# Altium schematic sheet 走马灯SCH.SchDoc(走马灯SCH)
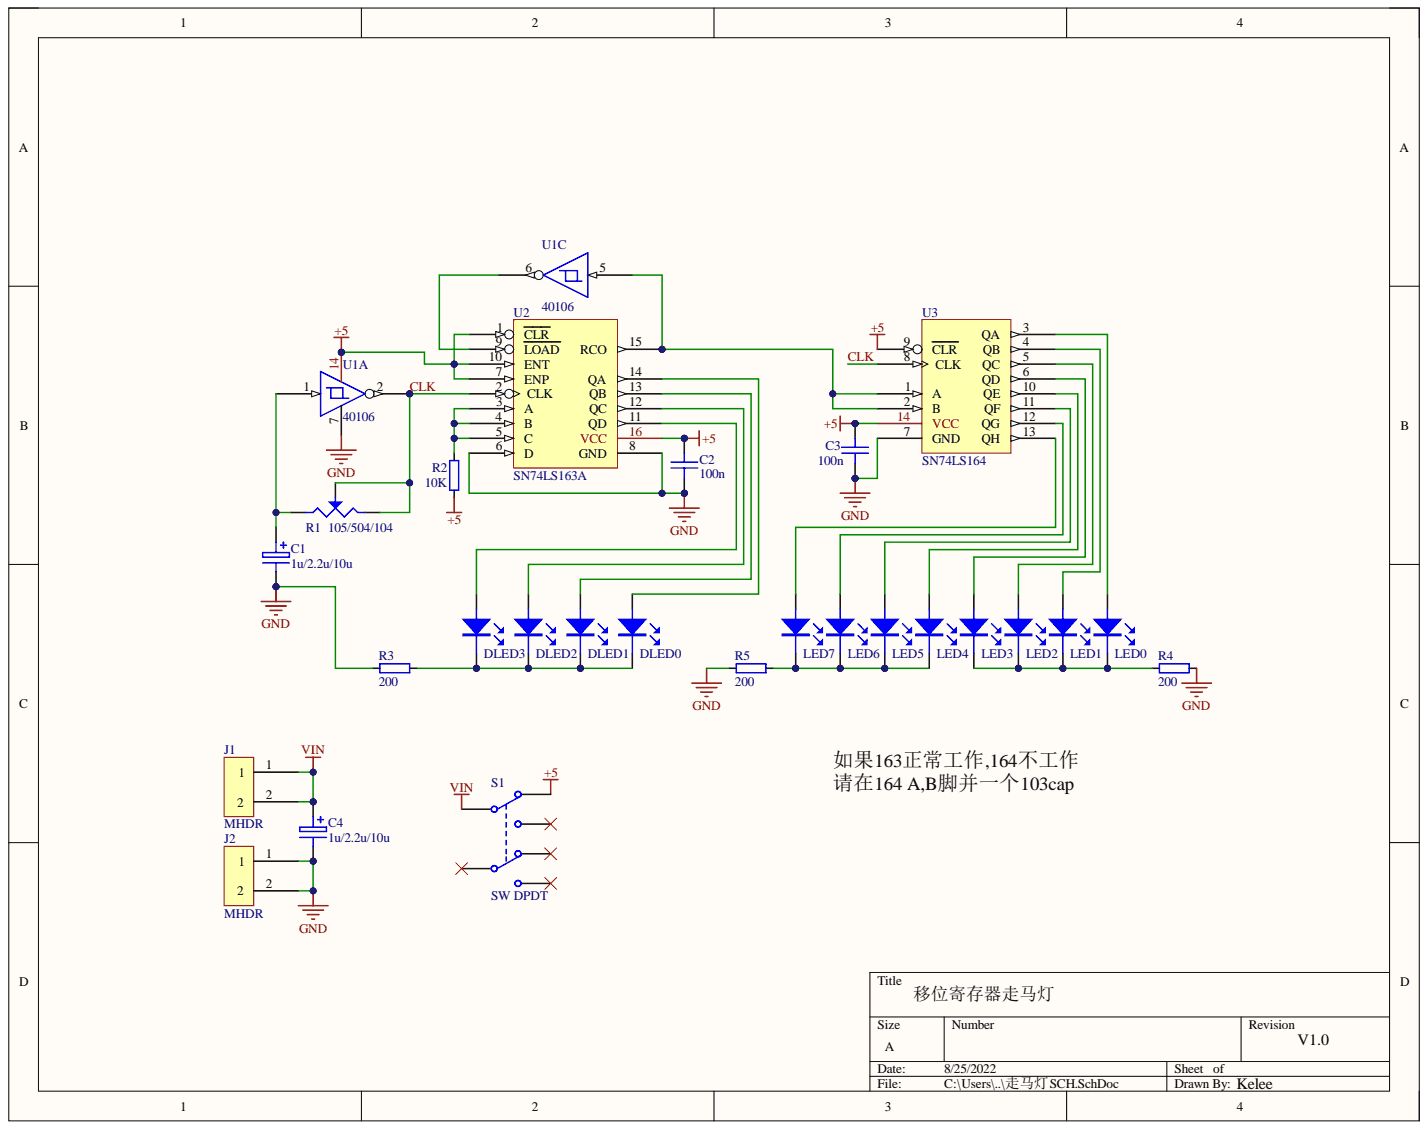
[diagram p0: 走马灯SCH.SchDoc]

## Parts
C1  1u/2.2u/10u  [C5X8]
C2  100n  [MLCC5.08-A]
C3  100n  [MLCC5.08-A]
C4  1u/2.2u/10u  [C5X8]
DLED0  D-LED  [LED 5MM-Y]
DLED1  D-LED  [LED 5MM-Y]
DLED2  D-LED  [LED 5MM-Y]
DLED3  D-LED  [LED 5MM-Y]
J1  MHDR  [HDR1X2]
J2  MHDR  [HDR1X2]
LED0  LED  [LED 5MM-R]
LED1  LED  [LED 5MM-R]
LED2  LED  [LED 5MM-R]
LED3  LED  [LED 5MM-R]
LED4  LED  [LED 5MM-R]
LED5  LED  [LED 5MM-R]
LED6  LED  [LED 5MM-R]
LED7  LED  [LED 5MM-R]
R1  105/504/104  [RES ADJ3]
R2  10K  [RS 1/4W]
R3  200  [RS 1/4W]
R4  200  [RS 1/4W]
R5  200  [RS 1/4W]
S1  SW DPDT  [KFT DIP-8X8]
U1  40106  [DIP14]  (parts A,C)
U2  SN74LS163A  [DIP16]
U3  SN74LS164  [DIP14]

## Nets
+5: C2.2 C3.2 R2.2 S1.1 U1.14 U2.1(CLR) U2.7(ENP) U2.10(ENT) U2.16(VCC) U3.9(CLR) U3.14(VCC)
GND: C1.2 C2.1 C3.1 C4.2 J2.1 J2.2 R3.1 R4.2 R5.1 U1.7 U2.6(D) U2.8 U3.7(GND)
VCC: U1.14 U2.16(VCC) U3.14(VCC)
VIN: C4.1 J1.1 J1.2 S1.3
CLK: R1.2 R1.3 U1.2 U2.2(CLK) U3.8(CLK)
NetC1_1: C1.1 R1.1 U1.1
NetDLED0_1: DLED0.1 U2.14(QA)
NetDLED0_2: DLED0.2 DLED1.2 DLED2.2 DLED3.2 R3.2
NetDLED1_1: DLED1.1 U2.13(QB)
NetDLED2_1: DLED2.1 U2.12(QC)
NetDLED3_1: DLED3.1 U2.11(QD)
NetLED0_1: LED0.1 U3.3(QA)
NetLED0_2: LED0.2 LED1.2 LED2.2 LED3.2 R4.1
NetLED1_1: LED1.1 U3.4(QB)
NetLED2_1: LED2.1 U3.5(QC)
NetLED3_1: LED3.1 U3.6(QD)
NetLED4_1: LED4.1 U3.10(QE)
NetLED4_2: LED4.2 LED5.2 LED6.2 LED7.2 R5.2
NetLED5_1: LED5.1 U3.11(QF)
NetLED6_1: LED6.1 U3.12(QG)
NetLED7_1: LED7.1 U3.13(QH)
NetR2_1: R2.1 U2.3(A) U2.4(B) U2.5(C)
NetU1_5: U1.5 U2.15(RCO) U3.1(A) U3.2(B)
NetU1_6: U1.6 U2.9(LOAD)

Not connected: S1 2 4 5 6

## Library data of the parts
C1 C4 = CAP-E: 电解电容; Component Kind: Standard; Library Name: 走马灯SCH.SchLib
C2 C3 = CAP-M: 独石电容; Component Kind: Standard; Library Name: 走马灯SCH.SchLib
DLED0-DLED3 = D-LED: LED; Component Kind: Standard; Library Name: 走马灯SCH.SchLib
J1 J2 = MHDR1x2: Component Kind: Standard; Description: MHDR1x2; Library Name: 走马灯SCH.SchLib
R1 = R-GLIDE: Component Kind: Standard; Library Name: 走马灯SCH.SchLib
R2 = R-10K: resistor; Component Kind: Standard; Library Name: 走马灯SCH.SchLib
R3-R5 = R-200: resistor; Component Kind: Standard; Library Name: 走马灯SCH.SchLib
S1 = SW DPDT: Switch; Component Kind: Standard; LatestRevisionDate: 17-Jul-2002; LatestRevisionNote: Re-released for DXP Platform.; PackageReference: SOT23-6; Published: 24-Mar-1999; Library Name: 走马灯SCH.SchLib; Publisher: Altium Limited
U1 = CD40106: Component Kind: Standard; Library Name: 走马灯SCH.SchLib
U2 = SN74LS163A: SYN 4-BIT CNT; Component Kind: Standard; Library Name: 走马灯SCH.SchLib
U3 = SN74LS164: 8-BIT PALL SERI SFT REG; Component Kind: Standard; Library Name: 走马灯SCH.SchLib
LED0-LED7 = LED: Component Kind: Standard; Description: LED; Library Name: 走马灯SCH.SchLib
